# Altium schematic sheet 主控芯片.SchDoc(主控芯片)
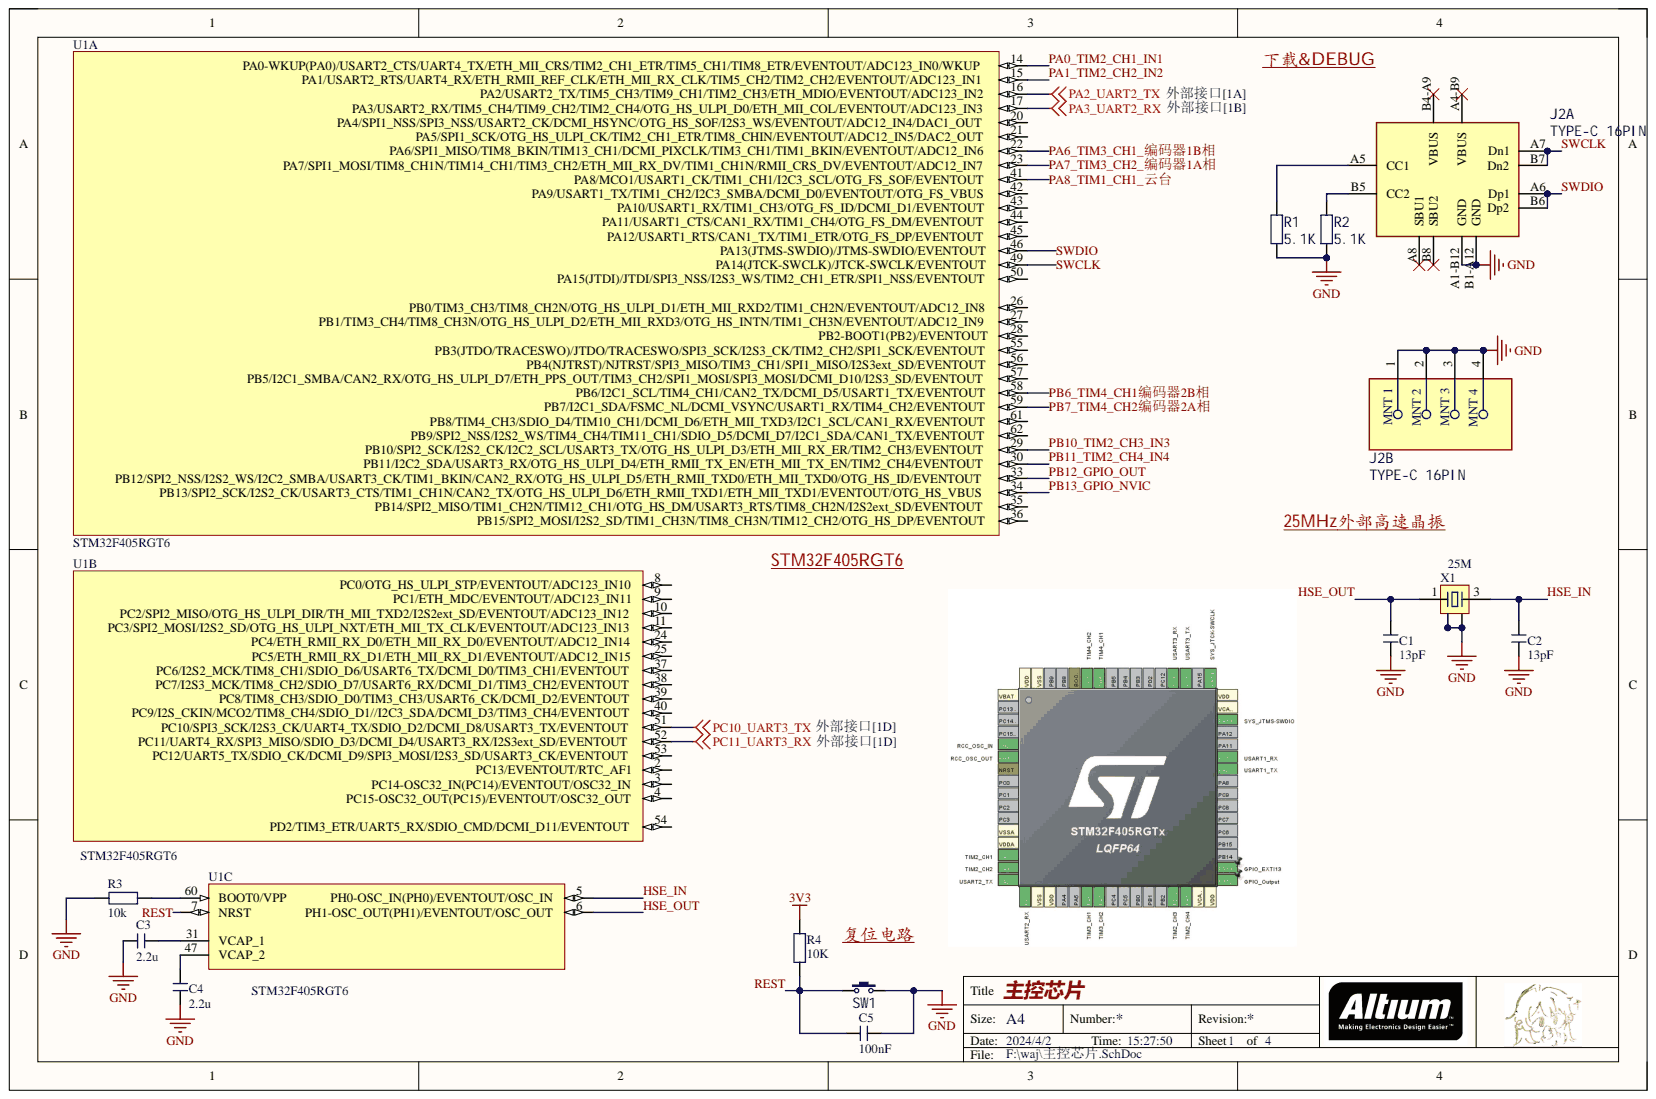
[diagram p0: 主控芯片.SchDoc]

## Parts
C1  13pF  [C 0603_L]
C2  13pF  [C 0603_L]
C3  2.2u  [C 0603_L]
C4  2.2u  [C 0603_L]
C5  100nF  [C 0603_L]
J2  TYPE-C 16PIN  [Molex_0_MFG]  (parts A,B)
R1  5.1K  [R 0603_L]
R2  5.1K  [R 0603_L]
R3  10k  [R 0603_L]
R4  10K  [R 0603_L]
SW1  6x6x5  [TS 2PIN 6x6x4.8]  (value not drawn)
U1  STM32F405RGT6  [LQFP64 10x10_M]  (parts A,B,C)
X1  25M  [OSC 3225-4P]

## Nets
3V3: R4.2
GND: C1.1 C2.1 C3.1 C4.1 C5.2 J2.1 J2.2 J2.3 J2.4 J2.A1-B12 J2.B1-A12 R1.1 R2.1 R3.2 SW1.2 X1.2 X1.4
PA2_UART2_TX: U1.16
PA3_UART2_RX: U1.17
PC10_UART3_TX: U1.51
PC11_UART3_RX: U1.52
HSE_IN: C2.2 U1.5 X1.3
HSE_OUT: C1.2 U1.6 X1.1
PA0_TIM2_CH1_IN1: U1.14
PA1_TIM2_CH2_IN2: U1.15
PA6_TIM3_CH1_编码器1B相: U1.22
PA7_TIM3_CH2_编码器1A相: U1.23
PA8_TIM1_CH1_云台: U1.41
PB6_TIM4_CH1编码器2B相: U1.58
PB7_TIM4_CH2编码器2A相: U1.59
PB10_TIM2_CH3_IN3: U1.29
PB11_TIM2_CH4_IN4: U1.30
PB12_GPIO_OUT: U1.33
PB13_GPIO_NVIC: U1.34
REST: C5.1 R4.1 SW1.1 U1.7
SWCLK: J2.A7 J2.B7 U1.49
SWDIO: J2.A6 J2.B6 U1.46
NetC3_2: C3.2 U1.31
NetC4_2: C4.2 U1.47
NetJ2_A5: J2.A5 R1.2
NetJ2_B5: J2.B5 R2.2
NetR3_1: R3.1 U1.60

Not connected: J2 A4-B9 A8 B4-A9 B8; U1 2 3 4 8 9 10 11 20 21 24 25 26 27 28 35 36 37 38 39 40 42 43 44 45 50 53 54 55 56 57 61 62

## Library data of the parts
C1-C5 = C: 贴片电容; BOM名称: 无极性贴片电容; 淘宝ID: 封装库来自淘宝 ID：黄晶宝石; Component Kind: Standard; Library Name: 0.1 - 电阻-电容-电感.SchLib; 唯一出售店铺网址: https://shop463601444.taobao.com; 联系售后，技术支持: https://shop463601444.taobao.com
J2 = TYPE-C 16PIN 2MD: Universal Serial Bus (USB) Shielded I/O Receptacle, Type C, Right-Angle, Surface  Mount, Top Mount, Single Row, 16 Circuits, Gold (Au) Plating; Component Kind: Standard; Library Name: CMD_G474.SCHLIB
R1 R2 = R: 贴片电阻; BOM名称: 贴片电阻; Component Kind: Standard; Library Name: 3.1   - 电阻-电容-电感.SchLib
R3 R4 = R: 贴片电阻; 封装库来自淘宝卖家 ID：黄晶宝石: 其他店铺购入均为盗版，不享受售后技术支持服务，请立即申请退款; 版权归属: 来自淘宝 ID：黄晶宝石; BOM名称: 贴片电阻; Component Kind: Standard; Library Name: 0.1 - 电阻-电容-电感.SchLib; 联系售后: https://shop463601444.taobao.com
SW1 = TSW SMD-2Pin-6x6: 2脚贴片6x6无声硅胶轻触开关; BOM名称: 2脚轻触开关; Component Kind: Standard; Library Name: CMD_G474.SCHLIB
U1 = STM32F405RGT6: ARM Cortex-M4 32b MCU+FPU, 210DMIP, 1024 kB Flash, 192+4 kB RAM, 51 I/Os, 64-pin LQFP, -40 to +85癈, Tray; 16-bit Timers (IC/OC/PWM): 12x16-bit(24/24/30); 32-bit Timer (IC/OC/PWM): 2x32-bit (8/8/8); A/D Converter: 16x12-bit; D/A Converter: 2x12-bit; Internal RAM: 192kB; Operating Frequency (Processor Speed): 168MHz; Other Timer Functions: 2xWDG, RTC, 24-bit down counter; Serial Interface: 3xSPI/2xI2S/2xI2C/3xUSART(IrDa, ISO7816)/3xUART/1xUSB OTG FS/HS, 2xCAN/ SDIO; Component Kind: Standard; ComponentLink1Description: Manufacturer URL; ComponentLink1URL: http://www.st.com/; ComponentLink2Description: STM32F405/7 Datasheet; ComponentLink2URL: http://www.st.com/internet/com/TECHNICAL_RESOURCES/TECHNICAL_LITERATURE/DATASHEET/DM00037051.pdf; ComponentLink3Description: STM32F4 Web Page; ComponentLink3URL: http://www.st.com/internet/mcu/subclass/1521.jsp; DatasheetVersion: rev2, Jan-2012; Library Name: 2.5 - STM32 F4系列单片机.SchLib; PackageDescription: 64-Pin Low Profile Quad Flat Package, 10x10 mm Body, 0.5 mm Pitch; PackageReference: TQFP64; PackageVersion: 2012
X1 = XTAL-4P: 4脚无源晶振; 版权归属: 封装库来自淘宝卖家 ID：黄晶宝石; BOM名称: 4脚无源晶振; Component Kind: Standard; Library Name: 2.0 - 保险丝-晶振-光耦.SchLib; 学习资源: https://shop463601444.taobao.com
